# Altium schematic sheet Judge.SchDoc(Judge)
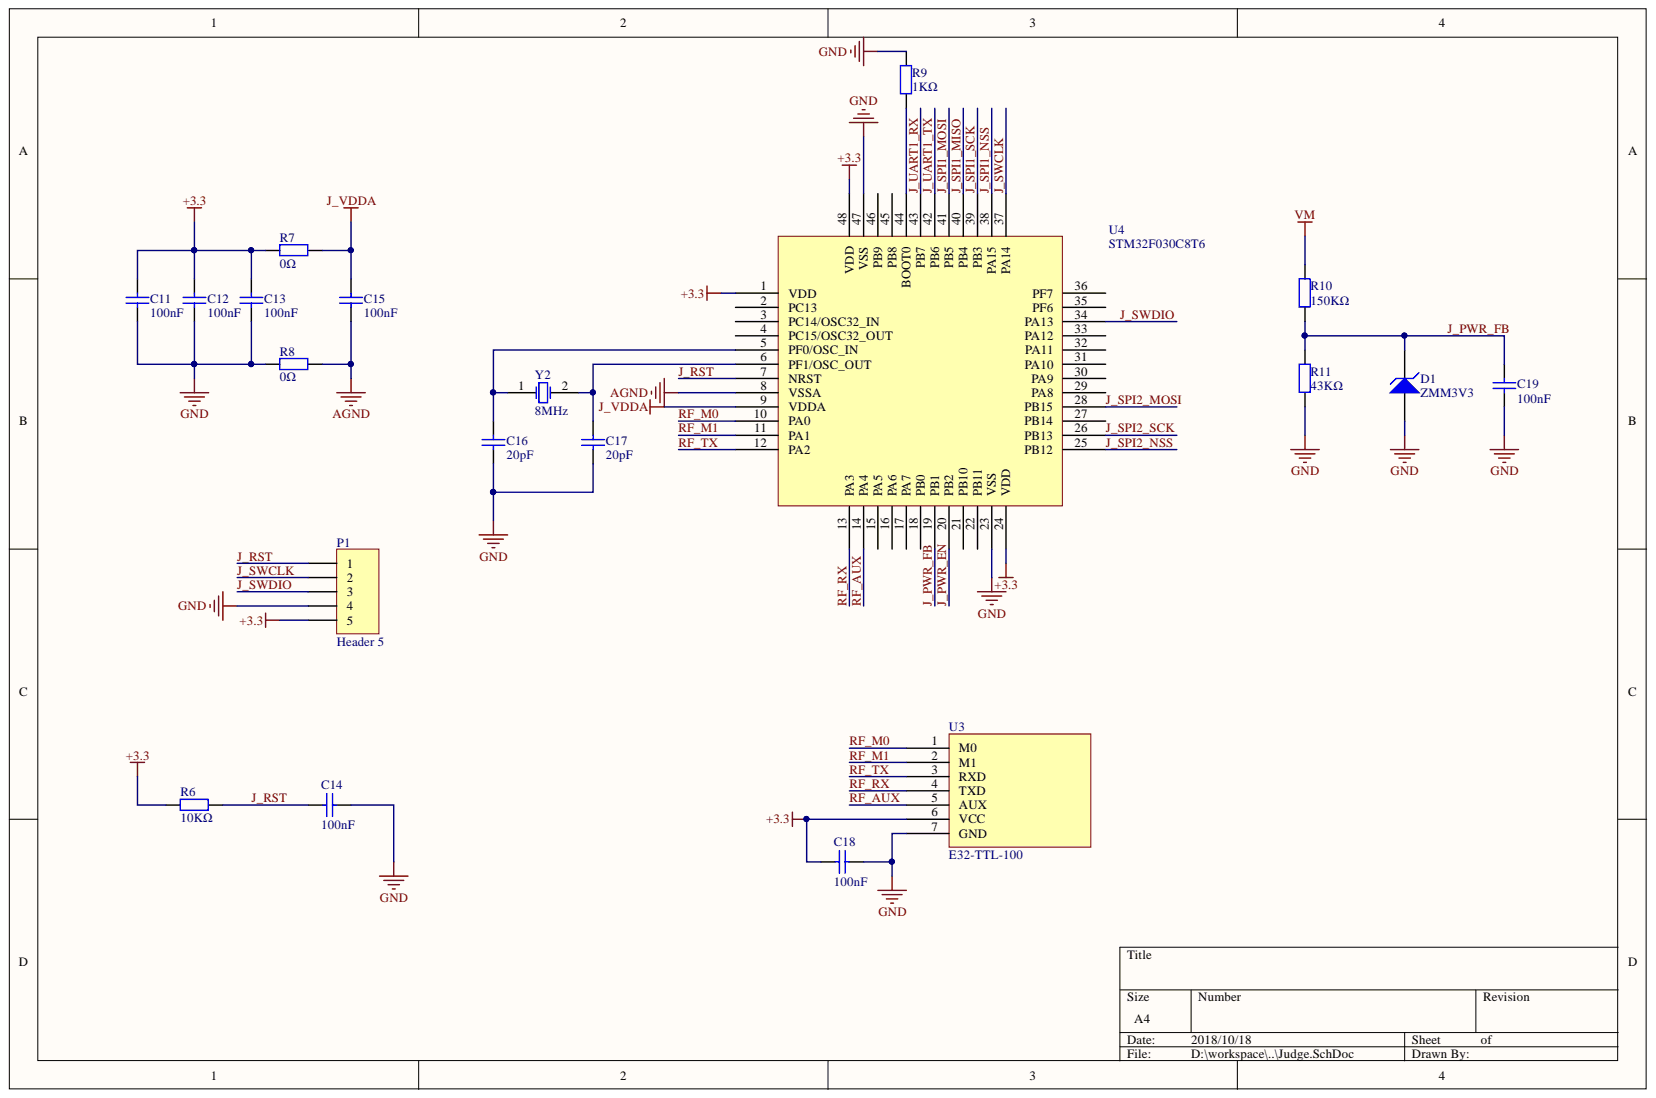
[diagram p0: Judge.SchDoc]

## Parts
C11  100nF  [0603_C]
C12  100nF  [0603_C]
C13  100nF  [0603_C]
C14  100nF  [0402_C]
C15  100nF  [0603_C]
C16  20pF  [0603_C]
C17  20pF  [0603_C]
C18  100nF  [0603_C]
C19  100nF  [0603_C]
D1  ZMM3V3  [LL-34]
P1  Header 5  [HDR1X5]
R6  10KΩ  [0402_R]
R7  0Ω  [0603_R]
R8  0Ω  [0603_R]
R9  1KΩ  [0603_R]
R10  150KΩ  [0603_R]
R11  43KΩ  [0603_R]
U3  E32-TTL-100  [E32-TTL-100]
U4  STM32F030C8T6  [LQFP-48_7x7x05P]
Y2  8MHz  [SMD-5032_2P]

## Nets
+3.3: C11.2 C12.2 C13.2 C18.2 P1.5 R6.1 R7.2 U3.6(VCC) U4.1(VDD) U4.24(VDD) U4.48(VDD)
AGND: C15.1 R8.1 U4.8(VSSA)
GND: C11.1 C12.1 C13.1 C14.2 C16.1 C17.1 C18.1 C19.1 D1.1 P1.4 R8.2 R9.2 R11.1 U3.7(GND) U4.23(VSS) U4.47(VSS)
J_VDDA: C15.2 R7.1 U4.9(VDDA)
VM: R10.2
J_PWR_EN: U4.20(PB2)
J_PWR_FB: C19.2 D1.2 R10.1 R11.2 U4.19(PB1)
J_RST: C14.1 P1.1 R6.2 U4.7(NRST)
J_SPI1_MISO: U4.40(PB4)
J_SPI1_MOSI: U4.41(PB5)
J_SPI1_NSS: U4.38(PA15)
J_SPI1_SCK: U4.39(PB3)
J_SPI2_MOSI: U4.28(PB15)
J_SPI2_NSS: U4.25(PB12)
J_SPI2_SCK: U4.26(PB13)
J_SWCLK: P1.2 U4.37(PA14)
J_SWDIO: P1.3 U4.34(PA13)
J_UART1_RX: U4.43(PB7)
J_UART1_TX: U4.42(PB6)
RF_AUX: U3.5(AUX) U4.14(PA4)
RF_M0: U3.1(M0) U4.10(PA0)
RF_M1: U3.2(M1) U4.11(PA1)
RF_RX: U3.4(TXD) U4.13(PA3)
RF_TX: U3.3(RXD) U4.12(PA2)
NetC16_2: C16.2 U4.5(PF0/OSC_IN) Y2.1
NetC17_2: C17.2 U4.6(PF1/OSC_OUT) Y2.2
NetR9_1: R9.1 U4.44(BOOT0)

Not connected: U4 2(PC13) 3(PC14/OSC32_IN) 4(PC15/OSC32_OUT) 15(PA5) 16(PA6) 17(PA7) 18(PB0) 21(PB10) 22(PB11) 27(PB14) 29(PA8) 30(PA9) 31(PA10) 32(PA11) 33(PA12) 35(PF6) 36(PF7) 45(PB8) 46(PB9)

## Library data of the parts
C11-C13 C15 C18 C19 = 0603 100nF (104) 10% 50V: 贴片电容; SuppliersPartNumber: C14663; Supplier: LC; Package: 0603; Library Name: Cap.SchLib; ComponentLink1URL: http://www.szlcsc.com/product/details_15331.html; ComponentLink1Description: 供应商链接; Component Kind: Standard
C14 = 0402 100nF (104) 10% 16V: 贴片电容; SuppliersPartNumber: C1525; Supplier: LC; Package: 0402; Library Name: Cap.SchLib; ComponentLink1URL: http://www.szlcsc.com/product/details_1877.html; ComponentLink1Description: 供应商链接; Component Kind: Standard
C16 C17 = 0603 20pF (200) 5% 50V: 贴片电容; SuppliersPartNumber: C1648; Supplier: LC; Package: 0603; Library Name: Cap.SchLib; ComponentLink1URL: http://www.szlcsc.com/product/details_2000.html; ComponentLink1Description: 供应商链接; Component Kind: Standard
D1 = LL-34 ZMM3V3: 稳压二极管; SuppliersPartNumber: C8056; Supplier: LC; Package: LL-34; Library Name: Zener.SchLib; ComponentLink1URL: http://www.szlcsc.com/product/details_8535.html; ComponentLink1Description: 供应商链接; Component Kind: Standard
P1 = Header 5: Header, 5-Pin; Signal Integrity: Connector; Library Name: Miscellaneous Connectors.IntLib; LatestRevisionNote: Re-released for DXP Platform.; LatestRevisionDate: 17-Jul-2002; Component Kind: Standard; Publisher: Altium Limited
R6 = 0402 10KΩ (1002) 1%: 贴片电阻; SuppliersPartNumber: C25744; Supplier: LC; Package: 0402; Library Name: RES.SchLib; ComponentLink1URL: http://www.szlcsc.com/product/details_26487.html; ComponentLink1Description: 供应商链接; Component Kind: Standard
R7 R8 = 0603 0Ω (0R0) 1%: 贴片电阻; SuppliersPartNumber: C21189; Supplier: LC; Package: 0603; Library Name: RES.SchLib; ComponentLink1URL: http://www.szlcsc.com/product/details_21903.html; ComponentLink1Description: 供应商链接; Component Kind: Standard
R9 = 0603 1KΩ (1001) 1%: 贴片电阻; SuppliersPartNumber: C21190; Supplier: LC; Package: 0603; Library Name: RES.SchLib; ComponentLink1URL: http://www.szlcsc.com/product/details_21904.html; ComponentLink1Description: 供应商链接; Component Kind: Standard
R10 = 0603 150KΩ (1503) 1%: 贴片电阻; SuppliersPartNumber: C22807; Supplier: LC; Package: 0603; Library Name: RES.SchLib; ComponentLink1URL: http://www.szlcsc.com/product/details_23534.html; ComponentLink1Description: 供应商链接; Component Kind: Standard
R11 = 0603 43KΩ (4302) 1%: 贴片电阻; SuppliersPartNumber: C23172; Supplier: LC; Package: 0603; Library Name: RES.SchLib; ComponentLink1URL: http://www.szlcsc.com/product/details_23899.html; ComponentLink1Description: 供应商链接; Component Kind: Standard
U4 = STM32F030C8T6: ARM; SuppliersPartNumber: C23922; Supplier: LC; Package: LQFP-48_7x7x05P; Library Name: MCU.SchLib; ComponentLink1URL: http://www.szlcsc.com/product/details_24662.html; ComponentLink1Description: 供应商链接; Component Kind: Standard
Y2 = SMD-5032_2P 8MHz 20ppm 20pF: 贴片晶振; SuppliersPartNumber: C115962; Supplier: LC; Package: SMD-5032_2P; Library Name: CrystalOscillator.SchLib; ComponentLink1URL: http://www.szlcsc.com/product/details_117214.html; ComponentLink1Description: 供应商链接; Component Kind: Standard
U3 = E32-TTL-100: Library Name: SmartCar.SchLib; Component Kind: Standard
